# Altium schematic sheet USBasp-Schematics.SchDoc(USBasp-Schematics)
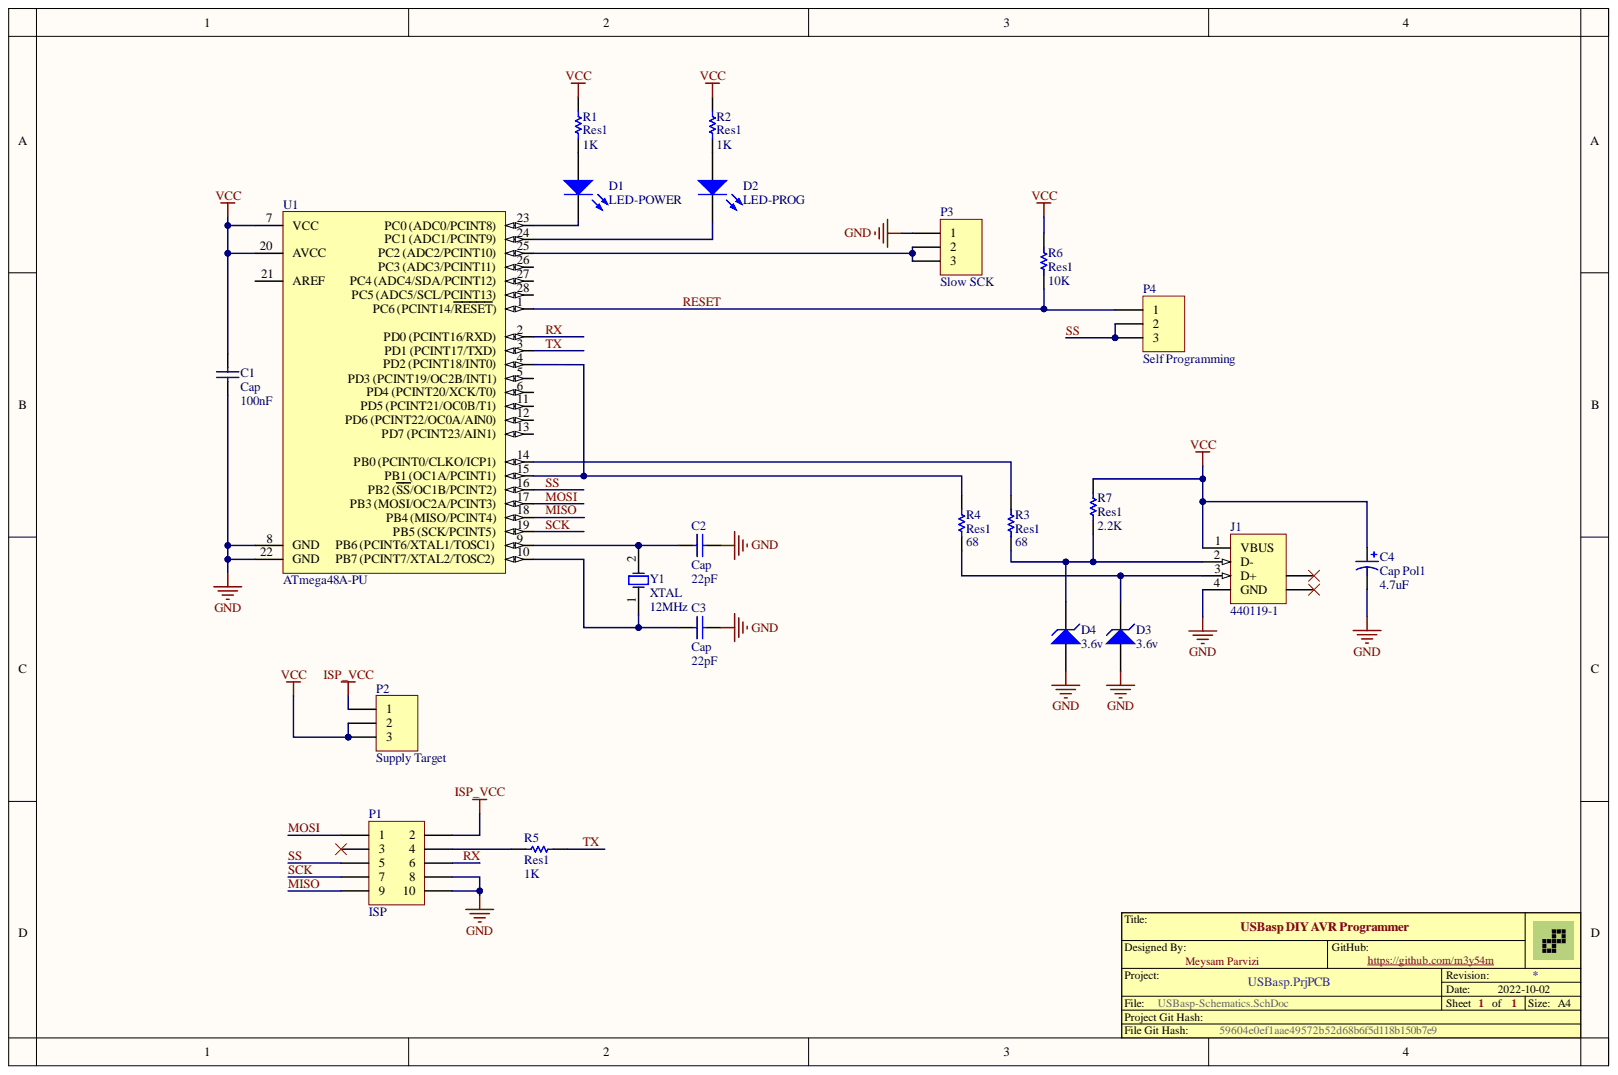
[diagram p0: USBasp-Schematics.SchDoc]

## Parts
C1  Cap  value 100nF  [RAD-0.1]
C2  Cap  value 22pF  [RAD-0.1]
C3  Cap  value 22pF  [RAD-0.1]
C4  Cap Pol1  value 4.7uF  [CAP1]
D1  LED-POWER  [LED1]
D2  LED-PROG  [LED1]
D3  3.6v  [DO-35]
D4  3.6v  [DO-35]
J1  440119-1  [440119]
P1  ISP  [BOX2X5]
P2  Supply Target  [HDR1X3]
P3  Slow SCK  [HDR1X3]
P4  Self Programming  [HDR1X3]
R1  Res1  value 1K  [AXIAL-0.3]
R2  Res1  value 1K  [AXIAL-0.3]
R3  Res1  value 68  [AXIAL-0.3]
R4  Res1  value 68  [AXIAL-0.3]
R5  Res1  value 1K  [AXIAL-0.3]
R6  Res1  value 10K  [AXIAL-0.3]
R7  Res1  value 2.2K  [AXIAL-0.3]
U1  ATmega48A-PU  [28P3]
Y1  XTAL  value 12MHz  [XTAL]

## Nets
GND: C1.1 C2.2 C3.2 C4.2 D3.1 D4.1 J1.4(GND) P1.8 P1.10 P3.1 U1.8(GND) U1.22(GND)
ISP_VCC: P1.2 P2.1
VCC: C1.2 C4.1 J1.1(VBUS) P2.2 P2.3 R1.2 R2.2 R6.2 R7.2 U1.7(VCC) U1.20(AVCC)
MISO: P1.9
MOSI: P1.1 U1.17(PB3_(MOSI/OC2A/PCINT3))
RESET: P4.1 R6.1 U1.1(PC6_(PCINT14/RESET))
RX: P1.6 U1.2(PD0_(PCINT16/RXD))
SCK: P1.7 U1.19(PB5_(SCK/PCINT5))
SS: P1.5 P4.2 P4.3 U1.16(PB2_(SS/OC1B/PCINT2))
TX: R5.2 U1.3(PD1_(PCINT17/TXD))
NetC2_1: C2.1 U1.9 Y1.2
NetC3_1: C3.1 U1.10 Y1.1
NetD1_1: D1.1 R1.1
NetD1_2: D1.2 U1.23(PC0_(ADC0/PCINT8))
NetD2_1: D2.1 R2.1
NetD2_2: D2.2 U1.24(PC1_(ADC1/PCINT9))
NetD3_2: D3.2 J1.3(D+) R4.1
NetD4_2: D4.2 J1.2(D-) R3.1 R7.1
NetP1_4: P1.4 R5.1
NetP3_2: P3.2 P3.3 U1.25
NetR3_2: R3.2 U1.14(PB0_(PCINT0/CLKO/ICP1))
NetR4_2: R4.2 U1.4(PD2_(PCINT18/INT0)) U1.15(PB1_(OC1A/PCINT1))

Not connected: J1 5 6; P1 3; U1 5(PD3_(PCINT19/OC2B/INT1)) 6(PD4_(PCINT20/XCK/T0)) 11(PD5_(PCINT21/OC0B/T1)) 12(PD6_(PCINT22/OC0A/AIN0)) 13(PD7_(PCINT23/AIN1)) 18(PB4_(MISO/PCINT4)) 21(AREF) 26(PC3_(ADC3/PCINT11)) 27 28(PC5_(ADC5/SCL/PCINT13))

## Library data of the parts
C1-C3 = Cap: Capacitor; Component Kind: Standard; LatestRevisionDate: 17-Jul-2002; LatestRevisionNote: Re-released for DXP Platform.; Library Name: Miscellaneous Devices.IntLib; PackageDescription: Radial Cap, Thru-Hole; 2 Leads; 0.3 in Pin Spacing; PackageReference: RAD-0.3; Published: 8-Jun-2000; Signal Integrity: Cap; Simulation: CAP; Publisher: Altium Limited
C4 = Cap Pol1: Polarized Capacitor (Radial); Component Kind: Standard; LatestRevisionDate: 17-Jul-2002; LatestRevisionNote: Re-released for DXP Platform.; Library Name: Miscellaneous Devices.IntLib; PackageDescription: Polarized Capacitor (Radial); 2 Leads; PackageReference: RB7.6-15; Published: 8-Jun-2000; Signal Integrity: Cap Pol; Simulation: CAP; Publisher: Altium Limited
D1 D2 = LED: Typical RED GaAs LED; Designer: Meysam Parvizi; Email: meysam.parvizi.ir@gmail.com; Component Kind: Standard; Library Name: mp.IntLib; Weblog: http://mp.tabin.ir
D3 D4 = D Zener: Zener Diode; Component Kind: Standard; LatestRevisionDate: 17-Jul-2002; LatestRevisionNote: Re-released for DXP Platform.; Library Name: Miscellaneous Devices.IntLib; PackageDescription: Diode, Thru-Hole; 2 Leads; 0.7 in Pin Spacing; PackageReference: DIODE-0.7; Published: 8-Jun-2000; Signal Integrity: D Zener; Simulation: ZENER; Publisher: Altium Limited
J1 = 440119-1: USB 1.1, Right Angle, Thru-Hole, B Type, Receptacle, 4 Position, Beige; ContactMaterial: Copper Alloy; ContactPlating: Gold Flash over Nickel; DrillSize: Corresponds to nominal value.; PanelGround: Without; PCB_Layout: Complies with manufacturer's recommendation.; TerminationLength: 2.84mm [0.112in.]; Component Kind: Standard; ComponentLink1Description: Manufacturer Link; ComponentLink1URL: http://www.tycoelectronics.com/; ComponentLink2Description: Packaging Specification; ComponentLink2URL: http://ecommas.tycoelectronics.com/commerce/DocumentDelivery/DDEController?Action=showdoc&DocId=Customer+Drawing%7F440119%7FC%7Ftif%7FEnglish%7FC_440119_C.tif; DatasheetDocument: 12-Jan-2004; HousingMaterial: Thermoplastic; Library Name: AMP Serial Bus USB.IntLib; PackageDocument: 12-Jan-2004; PackageReference: 440119; Published: 15-Jul-2004; Signal Integrity: USB1.1; Publisher: Altium Limited
P1 = Box 5X2: Box, 5-Pin, Dual row; Designer: Meysam Parvizi; Email: meysam.parvizi.ir@gmail.com; Component Kind: Standard; Library Name: mp.IntLib; Weblog: http://mp.tabin.ir
P2-P4 = Header 3: Header, 3-Pin; Component Kind: Standard; LatestRevisionDate: 17-Jul-2002; LatestRevisionNote: Re-released for DXP Platform.; Library Name: Miscellaneous Connectors.IntLib; Signal Integrity: Connector; Publisher: Altium Limited
R1-R7 = Res1: Resistor; Component Kind: Standard; LatestRevisionDate: 17-Jul-2002; LatestRevisionNote: Re-released for DXP Platform.; Library Name: Miscellaneous Devices.IntLib; PackageDescription: Axial Device, Thru-Hole; 2 Leads; 0.3 in Pin Spacing; PackageReference: AXIAL-0.3; Published: 8-Jun-2000; Signal Integrity: Res; Simulation: RESISTOR; Publisher: Altium Limited
U1 = ATmega48A-PU: 8-bit AVR Microcontroller, 4KB Flash, 256 Bytes EEPROM, 512 Bytes SRAM, 28-pin PDIP, Industrial Grade (-40°C to 85°C); Component Kind: Standard; ComponentLink1Description: Manufacturer URL; ComponentLink1URL: http://www.atmel.com/; ComponentLink2Description: ATmega48A/48PA/88A/88PA/168A/168PA/328/328P Datasheet; ComponentLink2URL: http://www.atmel.com/dyn/resources/prod_documents/8271.pdf; ComponentLink3Description: ATmega48A/48PA/88A/88PA/168A/168PA/328/328P Summary; ComponentLink3URL: http://www.atmel.com/dyn/resources/prod_documents/8271S.pdf; DatasheetVersion: revD, May-2011; Library Name: Atmel Microcontroller megaAVR.IntLib; PackageDescription: 28-lead (0.300"/7.62mm Wide) Plastic Dual Inline Package (PDIP); PackageReference: 28P3; PackageVersion: revB, Sep-2001
Y1 = XTAL: Crystal Oscillator; Designer: Meysam Parvizi; Email: meysam.parvizi.ir@gmail.com; Component Kind: Standard; Library Name: mp.IntLib; Weblog: http://mp.tabin.ir
